# Altium schematic sheet IPM_VFD.SchDoc(IPM_VFD)
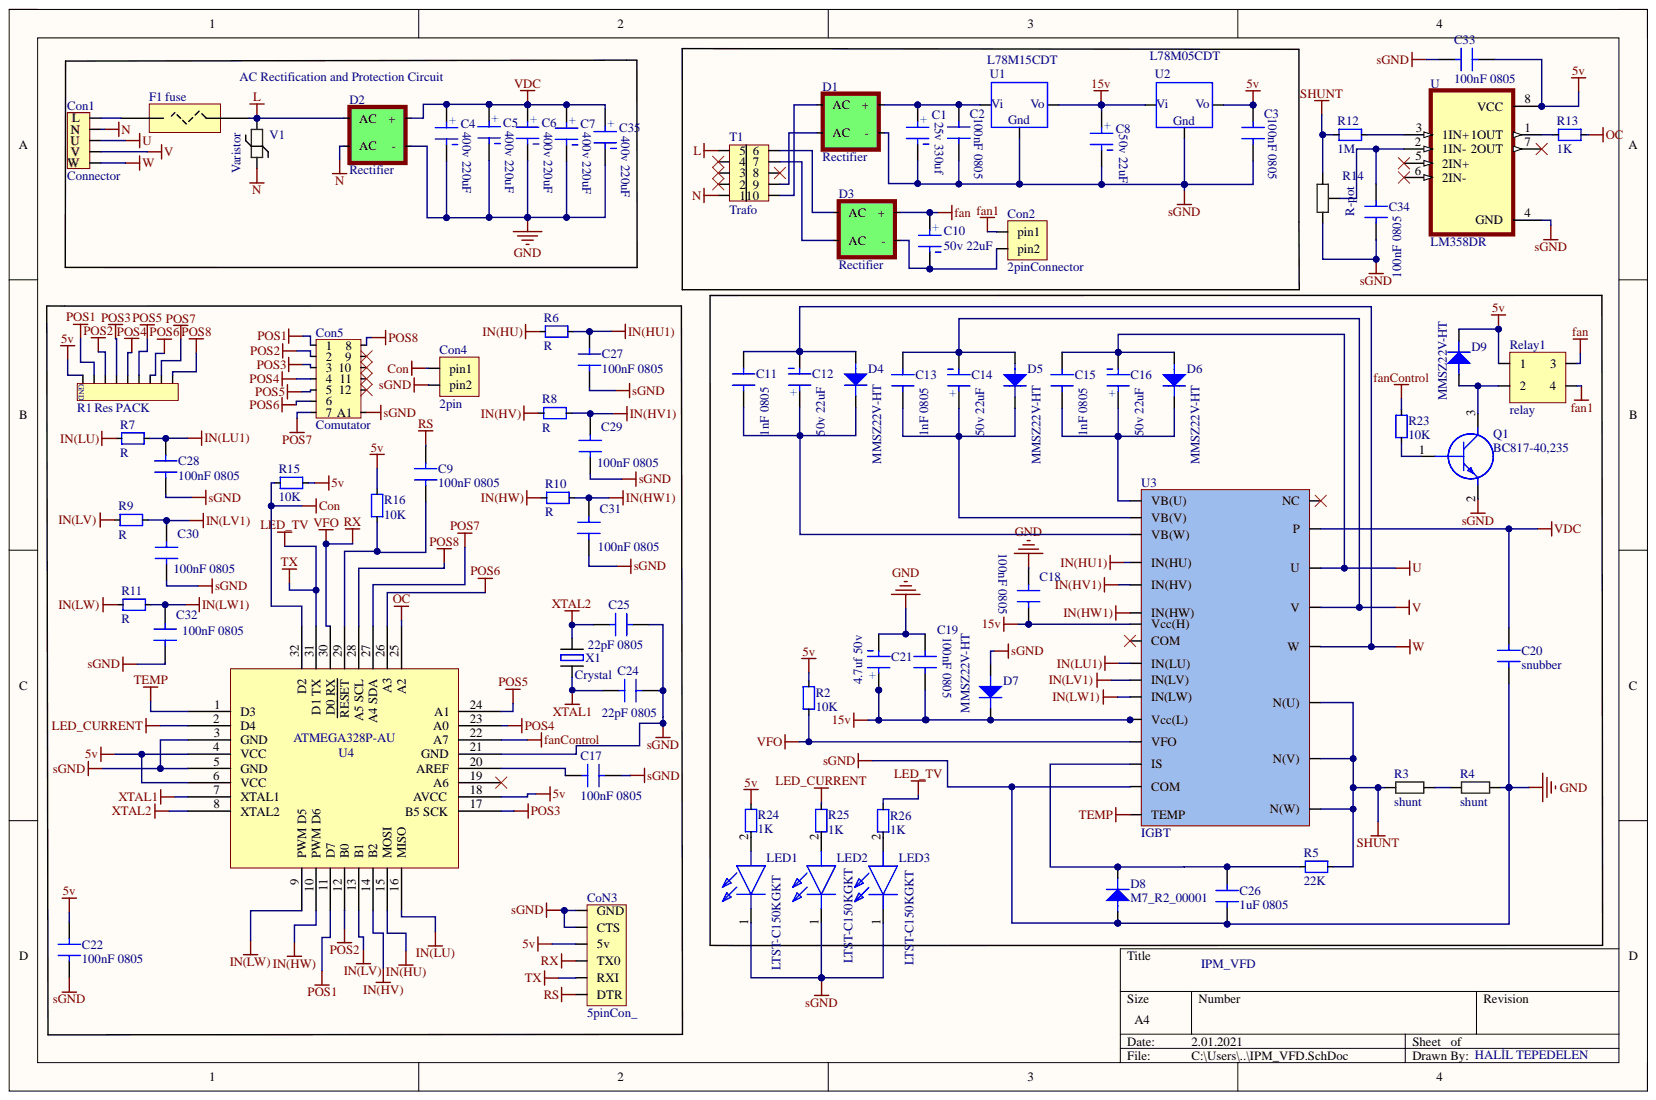
[diagram p0: IPM_VFD.SchDoc]

## Parts
C1  25v 330uf  [10*10 capacitor]
C2  100nF 0805  [C-0805R]
C3  100nF 0805  [C-0805R]
C4  400v 220uF  [400 220Uf]
C5  400v 220uF  [400 220Uf]
C6  400v 220uF  [400 220Uf]
C7  400v 220uF  [400 220Uf]
C8  50v 22uF  [50v 22uf]
C9  100nF 0805  [C-0805R]
C10  50v 22uF  [50v 22uf]
C11  1nF 0805  [C-0805R]
C12  50v 22uF  [50v 22uf]
C13  1nF 0805  [C-0805R]
C14  50v 22uF  [50v 22uf]
C15  1nF 0805  [C-0805R]
C16  50v 22uF  [50v 22uf]
C17  100nF 0805  [C-0805R]
C18  100nF 0805  [C-0805R]
C19  100nF 0805  [C-0805R]
C20  snubber  [snubber]
C21  4.7uf 50v  [4x5.3 cap]
C22  100nF 0805  [C-0805R]
C24  22pF 0805  [C-0805R]
C25  22pF 0805  [C-0805R]
C26  1uF 0805  [C-0805R]
C27  100nF 0805  [C-0805R]
C28  100nF 0805  [C-0805R]
C29  100nF 0805  [C-0805R]
C30  100nF 0805  [C-0805R]
C31  100nF 0805  [C-0805R]
C32  100nF 0805  [C-0805R]
C33  100nF 0805  [C-0805R]
C34  100nF 0805  [C-0805R]
C35  400v 220uF  [400 220Uf]
Con1  Connector  [Connector]
Con2  2pinConnector  [2pinCon]
CoN3  5pinCon_  [5pinCon]
Con4  2pin  [Contactor]
Con5  Comutator  [comutator]
D1  Rectifier  [bridge]
D2  Rectifier  [Bridge Rectifier]
D3  Rectifier  [bridge]
D4  MMSZ22V-HT  [SOD123]
D5  MMSZ22V-HT  [SOD123]
D6  MMSZ22V-HT  [SOD123]
D7  MMSZ22V-HT  [SOD123]
D8  M7_R2_00001  [DO-214AC]
D9  MMSZ22V-HT  [SOD123]
F1  fuse  [fuse holder]
LED1  LTST-C150KGKT  [led]
LED2  LTST-C150KGKT  [led]
LED3  LTST-C150KGKT  [led]
Q1  BC817-40,235  [SOT95P230X110-3N]
R1  Res PACK  [resistor Pack]
R2  10K  [R-0805R]
R3  shunt  [shunt]
R4  shunt  [shunt]
R5  22K  [R-0805R]
R6  R  [R-0805R]
R7  R  [R-0805R]
R8  R  [R-0805R]
R9  R  [R-0805R]
R10  R  [R-0805R]
R11  R  [R-0805R]
R12  1M  [R-0805R]
R13  1K  [R-0805R]
R14  R-pot  [pot]
R15  10K  [R-0805R]
R16  10K  [R-0805R]
R23  10K  [R-0805R]
R24  1K  [R-0805R]
R25  1K  [R-0805R]
R26  1K  [R-0805R]
Relay1  relay  [Relay]
T1  Trafo  [trafo]
U  LM358DR  [SOIC127P599X175-8N]
U1  L78M15CDT  [TO-252]
U2  L78M05CDT  [TO-252]
U3  IGBT  [6MBP20XSF06050P]
U4  ATMEGA328P-AU  [TQFP80P900X900X120-32N]
V1  Varistor  [varistor]
X1  Crystal  [crystal]

## Nets
5v: C3.2 C22.1 C33.1 CoN3.4(5v) D9.k R1.1 R2.2 R15.1 R16.1 R24.2 Relay1.1 U.8(VCC) U2.2 U4.4(VCC) U4.6(VCC) U4.18(AVCC)
15v: C8.1 C18.1 C19.1 C21.1 D7.k U1.2 U2.1 U3.12(Vcc(H)) U3.17(Vcc(L))
Con: Con4.1 R15.2 U4.32(D2)
fan: C10.1 D3.1(+) Relay1.3
fan1: Con2.1 Relay1.4
fanControl: R23.2 U4.22(A7)
GND: C1.2 C2.1 C3.1 C4.1 C5.1 C6.1 C7.1 C8.2 C17.2 C18.2 C19.2 C20.2 C21.2 C22.2 C24.2 C25.2 C26.2 C27.2 C28.2 C29.1 C30.1 C31.1 C32.1 C33.2 C34.2 C35.1 CoN3.5(CTS) CoN3.6(GND) Con4.2 Con5.A1 D1.2(-) D2.3(-) D7.a D8.a LED1.1 LED2.1 LED3.1 Q1.2 R4.2 R14.1 U.4(GND) U1.3 U2.3 U3.20(COM) U4.3(GND) U4.5(GND) U4.21(GND)
IN(HU1): C27.1 R6.2 U3.9(IN(HU))
IN(HU): R6.1 U4.15(MOSI)
IN(HV1): C29.2 R8.2 U3.10(IN(HV))
IN(HV): R8.1 U4.14(B2)
IN(HW1): C31.2 R10.2 U3.11(IN(HW))
IN(HW): R10.1 U4.10(PWM_D6)
IN(LU1): C28.1 R7.2 U3.14(IN(LU))
IN(LU): R7.1 U4.16(MISO)
IN(LV1): C30.2 R9.2 U3.15(IN(LV))
IN(LV): R9.1 U4.13(B1)
IN(LW1): C32.2 R11.2 U3.16(IN(LW))
IN(LW): R11.1 U4.9(PWM_D5)
L: D2.1(AC) F1.1 T1.5 V1.2
LED_CURRENT: R25.2 U4.2(D4)
LED_TV: CoN3.2(RXI) R26.2 U4.31(D1_TX)
N: Con1.2(N) D2.4(AC) T1.1 V1.1
OC: R13.2 U4.25(A2)
POS1: Con5.1 R1.2 U4.11(D7)
POS2: Con5.2 R1.3 U4.12(B0)
POS3: Con5.3 R1.4 U4.17(B5_SCK)
POS4: Con5.4 R1.5 U4.23(A0)
POS5: Con5.5 R1.6 U4.24(A1)
POS6: Con5.6 R1.7 U4.26(A3)
POS7: Con5.7 R1.8 U4.27(A4_SDA)
POS8: Con5.8 R1.9 U4.28(A5_SCL)
RS: C9.2 CoN3.1(DTR)
RX: CoN3.3(TX0) R2.1 U3.18(VFO) U4.30(D0_RX)
SHUNT: R3.1 R5.2 R12.1 R14.3 U3.22(N(W)) U3.23(N(V)) U3.24(N(U))
TEMP: U3.21(TEMP) U4.1(D3)
U: C15.1 C16.2 Con1.3(U) D6.a U3.30(U)
V: C13.1 C14.2 Con1.4(V) D5.a U3.28(V)
VDC: C4.2 C5.2 C6.2 C7.2 C20.1 C35.2 D2.2(+) U3.32(P)
W: C11.1 C12.2 Con1.5(W) D4.a U3.26(W)
XTAL1: C24.1 U4.7(XTAL1) X1.1
XTAL2: C25.1 U4.8(XTAL2) X1.2
NetC1_1: C1.1 C2.2 D1.1(+) U1.1
NetC9_1: C9.1 R16.2 U4.29(RESET)
NetC10_2: C10.2 Con2.2 D3.2(-)
NetC11_2: C11.2 C12.1 D4.k U3.7(VB(W))
NetC13_2: C13.2 C14.1 D5.k U3.5(VB(V))
NetC15_2: C15.2 C16.1 D6.k U3.3(VB(U))
NetC17_1: C17.1 U4.20(AREF)
NetC26_1: C26.1 D8.k R5.1 U3.19(IS)
NetC34_1: C34.1 R14.2 U.2(1IN-)
NetCon1_1: Con1.1(L) F1.2
NetD1_3: D1.3(AC) T1.9
NetD1_4: D1.4(AC) T1.10
NetD3_3: D3.3(AC) T1.7
NetD3_4: D3.4(AC) T1.6
NetD9_a: D9.a Q1.3 Relay1.2
NetLED1_2: LED1.2 R24.1
NetLED2_2: LED2.2 R25.1
NetLED3_2: LED3.2 R26.1
NetQ1_1: Q1.1 R23.1
NetR3_2: R3.2 R4.1
NetR12_2: R12.2 U.3(1IN+)
NetR13_1: R13.1 U.1(1OUT)

Not connected: Con5 9 10 11 12; T1 2 3 4 8; U 5(2IN+) 6(2IN-) 7(2OUT); U3 13(COM) 36(NC); U4 19(A6)

## Library data of the parts
C2 C3 C9 C17-C19 C22 C27-C34 = 100nF 0805: Manufacturer: Nichicon; Manufacturer Part Number: LKS1J472MESZ; Life (Hours): 1000Hour; Ripple Current: 3A; Tolerance: 20%; Voltage Rating: 63V; Case/Package: Radial; Component Kind: Standard; ComponentLink2Description: Link; ComponentLink2URL: https://ozdisan.com/Product/Detail/342152/CL21F104ZBANNNC; Diameter: 22mm; Height - Seated (Max): 1.772inch; Lead Pitch: 0.394inch; Length: 45mm; Max Operating Temperature: 85°C; Min Operating Temperature: -40°C; Mount: Through Hole; Package Quantity: 250; Packaging: Bulk; RoHS: Yes; Supplier 1: Mouser; Supplier Part Number 1: 647-LKS1J472MESZ; Termination: Snap in; Voltage Rating (DC): 63V; Library Name: IPM_VFD.SchLib
C4-C7 C35 = 400v 220uF: Manufacturer: Nichicon; Manufacturer Part Number: LKS1J472MESZ; Capacitance: 4.7mF; Life (Hours): 1000Hour; Ripple Current: 3A; Tolerance: 20%; Voltage Rating: 63V; Case/Package: Radial; Component Kind: Standard; ComponentLink2Description: https://www.ozdisan.com/pasif-komponentler/kondansatorler/aluminyum-kondansatorler/PKL5-063V472MM450; ComponentLink2URL: https://www.ozdisan.com/pasif-komponentler/kondansatorler/aluminyum-kondansatorler/PKL5-063V472MM450; Diameter: 22mm; Height - Seated (Max): 1.772inch; Lead Pitch: 0.394inch; Length: 45mm; Max Operating Temperature: 85°C; Min Operating Temperature: -40°C; Mount: Through Hole; Package Quantity: 250; Packaging: Bulk; RoHS: Yes; Supplier 1: Mouser; Supplier Part Number 1: 647-LKS1J472MESZ; Termination: Snap in; Voltage Rating (DC): 63V; Library Name: IPM_VFD.SchLib
C8 C10 C12 C14 C16 = 50v 22uF: Manufacturer: Nichicon; Manufacturer Part Number: LKS1J472MESZ; Capacitance: 4.7mF; Life (Hours): 1000Hour; Ripple Current: 3A; Tolerance: 20%; Voltage Rating: 63V; Case/Package: Radial; Component Kind: Standard; ComponentLink3Description: Link; ComponentLink3URL: https://ozdisan.com/passive-components/capacitors/aluminum-capacitors/MRW-050V220ME057-TR; Diameter: 22mm; Height - Seated (Max): 1.772inch; Lead Pitch: 0.394inch; Length: 45mm; Max Operating Temperature: 85°C; Min Operating Temperature: -40°C; Mount: Through Hole; Package Quantity: 250; Packaging: Bulk; RoHS: Yes; Supplier 1: Mouser; Supplier Part Number 1: 647-LKS1J472MESZ; Termination: Snap in; Voltage Rating (DC): 63V; Library Name: IPM_VFD.SchLib
C11 C13 C15 = 1nF 0805: Manufacturer: Nichicon; Manufacturer Part Number: LKS1J472MESZ; Life (Hours): 1000Hour; Ripple Current: 3A; Tolerance: 20%; Voltage Rating: 63V; Case/Package: Radial; Component Kind: Standard; ComponentLink1Description: Link; ComponentLink1URL: https://ozdisan.com/passive-components/capacitors/smt-smd-and-mlcc-capacitors/CL21B102KBANNNC; Diameter: 22mm; Height - Seated (Max): 1.772inch; Lead Pitch: 0.394inch; Length: 45mm; Max Operating Temperature: 85°C; Min Operating Temperature: -40°C; Mount: Through Hole; Package Quantity: 250; Packaging: Bulk; RoHS: Yes; Supplier 1: Mouser; Supplier Part Number 1: 647-LKS1J472MESZ; Termination: Snap in; Voltage Rating (DC): 63V; Library Name: IPM_VFD.SchLib
C20 = snubber: Manufacturer: Nichicon; Manufacturer Part Number: LKS1J472MESZ; Life (Hours): 1000Hour; Ripple Current: 3A; Tolerance: 20%; Voltage Rating: 63V; Case/Package: Radial; Component Kind: Standard; Diameter: 22mm; Height - Seated (Max): 1.772inch; Lead Pitch: 0.394inch; Length: 45mm; Max Operating Temperature: 85°C; Min Operating Temperature: -40°C; Mount: Through Hole; Package Quantity: 250; Packaging: Bulk; RoHS: Yes; Supplier 1: Mouser; Supplier Part Number 1: 647-LKS1J472MESZ; Termination: Snap in; Voltage Rating (DC): 63V; Library Name: IPM_VFD.SchLib
C24 C25 = 22pF 0805: Manufacturer: Nichicon; Manufacturer Part Number: LKS1J472MESZ; Life (Hours): 1000Hour; Ripple Current: 3A; Tolerance: 20%; Voltage Rating: 63V; Case/Package: Radial; Component Kind: Standard; ComponentLink1Description: Link; ComponentLink1URL: https://ozdisan.com/passive-components/capacitors/smt-smd-and-mlcc-capacitors/CL21C220JCANNNC; Diameter: 22mm; Height - Seated (Max): 1.772inch; Lead Pitch: 0.394inch; Length: 45mm; Max Operating Temperature: 85°C; Min Operating Temperature: -40°C; Mount: Through Hole; Package Quantity: 250; Packaging: Bulk; RoHS: Yes; Supplier 1: Mouser; Supplier Part Number 1: 647-LKS1J472MESZ; Termination: Snap in; Voltage Rating (DC): 63V; Library Name: IPM_VFD.SchLib
C26 = 1uF 0805: Manufacturer: Nichicon; Manufacturer Part Number: LKS1J472MESZ; Life (Hours): 1000Hour; Ripple Current: 3A; Tolerance: 20%; Voltage Rating: 63V; Case/Package: Radial; Component Kind: Standard; Diameter: 22mm; Height - Seated (Max): 1.772inch; Lead Pitch: 0.394inch; Length: 45mm; Max Operating Temperature: 85°C; Min Operating Temperature: -40°C; Mount: Through Hole; Package Quantity: 250; Packaging: Bulk; RoHS: Yes; Supplier 1: Mouser; Supplier Part Number 1: 647-LKS1J472MESZ; Termination: Snap in; Voltage Rating (DC): 63V; Library Name: IPM_VFD.SchLib
D1 D3 = Bridge Rectifier_1: Component Kind: Standard; ComponentLink1Description: Link; ComponentLink1URL: https://ozdisan.com/power-semiconductors/diodes-diode-modules-and-rectifiers/bridge-diodes/DF10S-HT; Library Name: IPM_VFD.SchLib
D2 = Bridge Rectifier: Component Kind: Standard; Library Name: IPM_VFD.SchLib
LED1-LED3 = LTST-C150KGKT: LED; Manufacturer_Name: Lite-On; Component Kind: Standard; Datasheet Link: http://optoelectronics.liteon.com/upload/download/ds22-2000-072/ltst-c150kgkt.pdf; Height: 1.2mm; Manufacturer_Part_Number: LTST-C150KGKT; Mouser Part Number: 859-LTST-C150KGKT; Mouser Price/Stock: https://www.mouser.com/Search/Refine.aspx?Keyword=859-LTST-C150KGKT; RS Part Number: 6920871; RS Price/Stock: https://uk.rs-online.com/web/p/products/6920871; Library Name: IPM_VFD.SchLib
Q1 = BC817-40,235: Transistor BJT NPN; Manufacturer_Name: Nexperia; Component Kind: Standard; Datasheet Link: http://assets.nexperia.com/documents/data-sheet/BC817_BC817W_BC337.pdf; Height: 1.1mm; Manufacturer_Part_Number: BC817-40,235; Mouser Part Number: 771-BC817-40235; Mouser Price/Stock: https://www.mouser.com/Search/Refine.aspx?Keyword=771-BC817-40235; RS Part Number: 1707957; RS Price/Stock: http://uk.rs-online.com/web/p/products/1707957; Library Name: IPM_VFD.SchLib
R2 R15 R16 R23 = 10K 0805: Component Kind: Standard; Library Name: IPM_VFD.SchLib
R3 R4 = shunt: Res1206; Component Kind: Standard; Library Name: IPM_VFD.SchLib
R5 = 22K 0805: Component Kind: Standard; Library Name: IPM_VFD.SchLib
R6-R11 = R 0805: Component Kind: Standard; Library Name: IPM_VFD.SchLib
R12 = 1M 0805: Component Kind: Standard; Library Name: IPM_VFD.SchLib
R13 R24-R26 = 1K 0805: Component Kind: Standard; Library Name: IPM_VFD.SchLib
R14 = R-pot: Res1206; Component Kind: Standard; Library Name: IPM_VFD.SchLib
U = LM358DR: Dual standard operational amplifier 8-SOIC 0 to 70; MF: Texas Instruments; Availability: Good; Component Kind: Standard; ComponentLink1Description: Link; ComponentLink1URL: https://ozdisan.com/Product/Detail/13257/LM358DR; DigiKey-Purchase-URL: https://snapeda.com/shop?store=DigiKey&id=231187; Mouser-Purchase-URL: https://snapeda.com/shop?store=Mouser&id=231187; MP: LM358DR; Package: SOIC-8 Texas Instruments; Price: None; SnapEDA_Link: https://www.snapeda.com/parts/LM358DR/Texas%20Instruments/view-part/231187/?ref=snap; Texas_Instruments-Purchase-URL: https://snapeda.com/shop?store=Texas+Instruments&id=231187; Library Name: IPM_VFD.SchLib
U1 = L78M15CDT: Manufacturer: STMicroelectronics; Dropout Voltage: 2V; Max Output Current: 500mA; Max Input Voltage: 35V; Number of Outputs: 1; Output Type: Fixed; Output Voltage: 15V; Polarity: Positive; Quiescent Current: 6mA; Case/Package: DPAK; Component Kind: Standard; ComponentLink1Description: link; ComponentLink1URL: https://karakoyelektronik.com/regulator/ua78m15-21734; Height: 2.4mm; Length: 6.6mm; Manufacturer Part Number: L78M15CDT; Max Operating Temperature: 150°C; Min Operating Temperature: 0°C; Min Input Voltage: 35V; Mount: Surface Mount; Number of Pins: 3; Output Current: 500mA; Package Quantity: 75; Packaging: Rail/Tube; Radiation Hardening: No; RoHS: Yes; Supplier 1: Avnet; Supplier Part Number 1: L78M15CDT; Width: 6.2mm; Library Name: IPM_VFD.SchLib
U2 = L78M05: Manufacturer: STMicroelectronics; Manufacturer Part Number: L78M15CDT; Dropout Voltage: 2V; Max Output Current: 500mA; Max Input Voltage: 35V; Number of Outputs: 1; Output Type: Fixed; Output Voltage: 15V; Polarity: Positive; Quiescent Current: 6mA; Case/Package: DPAK; Component Kind: Standard; ComponentLink1Description: Link; ComponentLink1URL: https://ozdisan.com/integrated-circuits-ics/power-management-ics/linear-voltage-regulators/MC7805BDTRKG; Height: 2.4mm; Length: 6.6mm; Max Operating Temperature: 150°C; Min Operating Temperature: 0°C; Min Input Voltage: 35V; Mount: Surface Mount; Number of Pins: 3; Output Current: 500mA; Package Quantity: 75; Packaging: Rail/Tube; Radiation Hardening: No; RoHS: Yes; Supplier 1: Avnet; Supplier Part Number 1: L78M15CDT; Width: 6.2mm; Library Name: IPM_VFD.SchLib
U3 = 6mbp20xsf060-50: Component Kind: Standard; Library Name: IPM_VFD.SchLib
U4 = ATMEGA328P-AU: Microchip Technology ATMEGA328P-AN, 8bit AVR Microcontroller, 20MHz, 32 kB Flash, 32-Pin TQFP; Component Kind: Standard; Library Name: IPM_VFD.SchLib
X1 = Crystal: AS49SD-16M-FC160F3-TR; Component Kind: Standard; Library Name: IPM_VFD.SchLib
C1 = 25v 330uf: Component Kind: Standard; ComponentLink1Description: Link; ComponentLink1URL: https://ozdisan.com/passive-components/capacitors/aluminum-capacitors/BXJ25VC330MJ10TP; Library Name: IPM_VFD.SchLib
C21 = 4.7uf 50v: Component Kind: Standard; Library Name: IPM_VFD.SchLib
Con1 = Connector: Component Kind: Standard; Library Name: IPM_VFD.SchLib
Con2 = 2pinConnector: Component Kind: Standard; Library Name: IPM_VFD.SchLib
CoN3 = 5pinCon_: Component Kind: Standard; Library Name: IPM_VFD.SchLib
Con4 = 2pin: Component Kind: Standard; Library Name: IPM_VFD.SchLib
Con5 = Comutator: Component Kind: Standard; Library Name: IPM_VFD.SchLib
D4-D7 D9 = MMSZ22V-HT: Component Kind: Standard; ComponentLink1Description: Link; ComponentLink1URL: https://ozdisan.com/power-semiconductors/diodes-diode-modules-and-rectifiers/zener-diodes/MMSZ22V-HT; Library Name: IPM_VFD.SchLib
D8 = M7_R2_00001: Component Kind: Standard; ComponentLink1Description: Link; ComponentLink1URL: https://ozdisan.com/power-semiconductors/diodes-diode-modules-and-rectifiers/general-purpose-diodes/M7R200001; Library Name: IPM_VFD.SchLib
F1 = fuse: Component Kind: Standard; Library Name: IPM_VFD.SchLib
R1 = Res pack: Component Kind: Standard; Library Name: IPM_VFD.SchLib
Relay1 = relay: Component Kind: Standard; Library Name: IPM_VFD.SchLib
T1 = Trafo: Component Kind: Standard; Library Name: IPM_VFD.SchLib
V1 = Varistor: Component Kind: Standard; ComponentLink1Description: Link; ComponentLink1URL: https://ozdisan.com/power-semiconductors/circuit-protection-components/varistors/TVR10391KSY; Library Name: IPM_VFD.SchLib
